# Altium schematic sheet interface.SchDoc(interface)
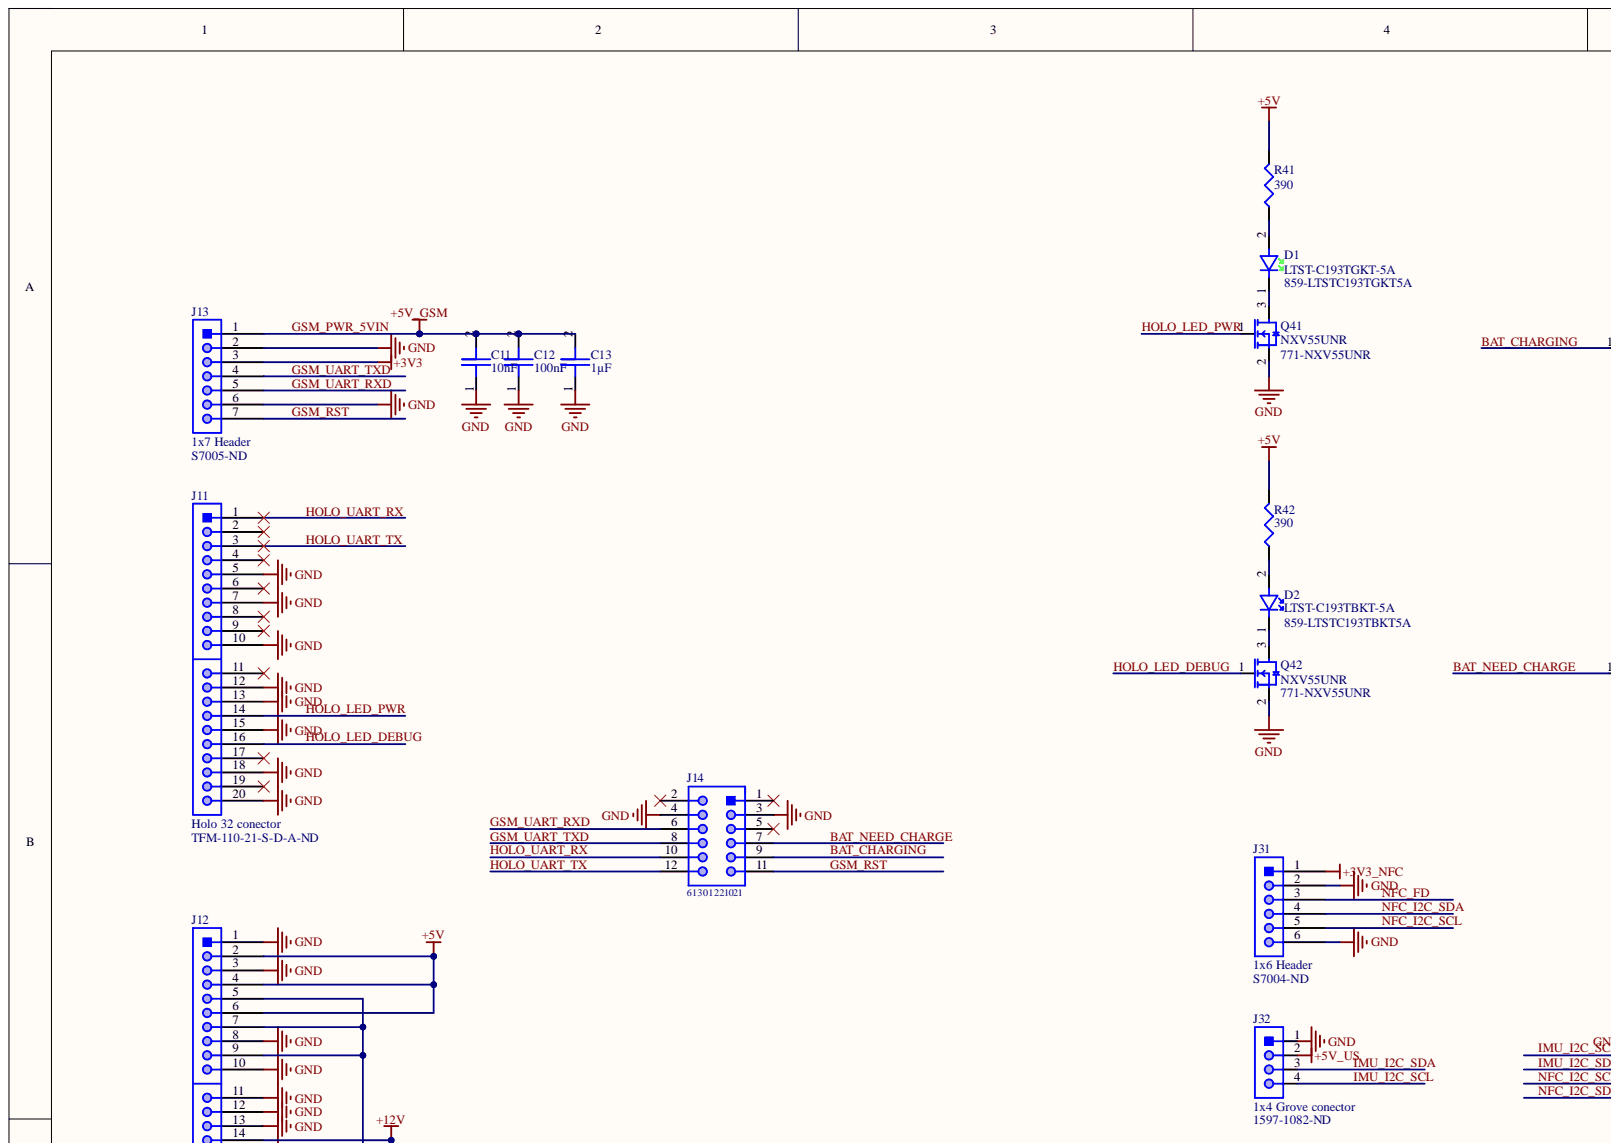
[diagram p0: interface.SchDoc, top left]
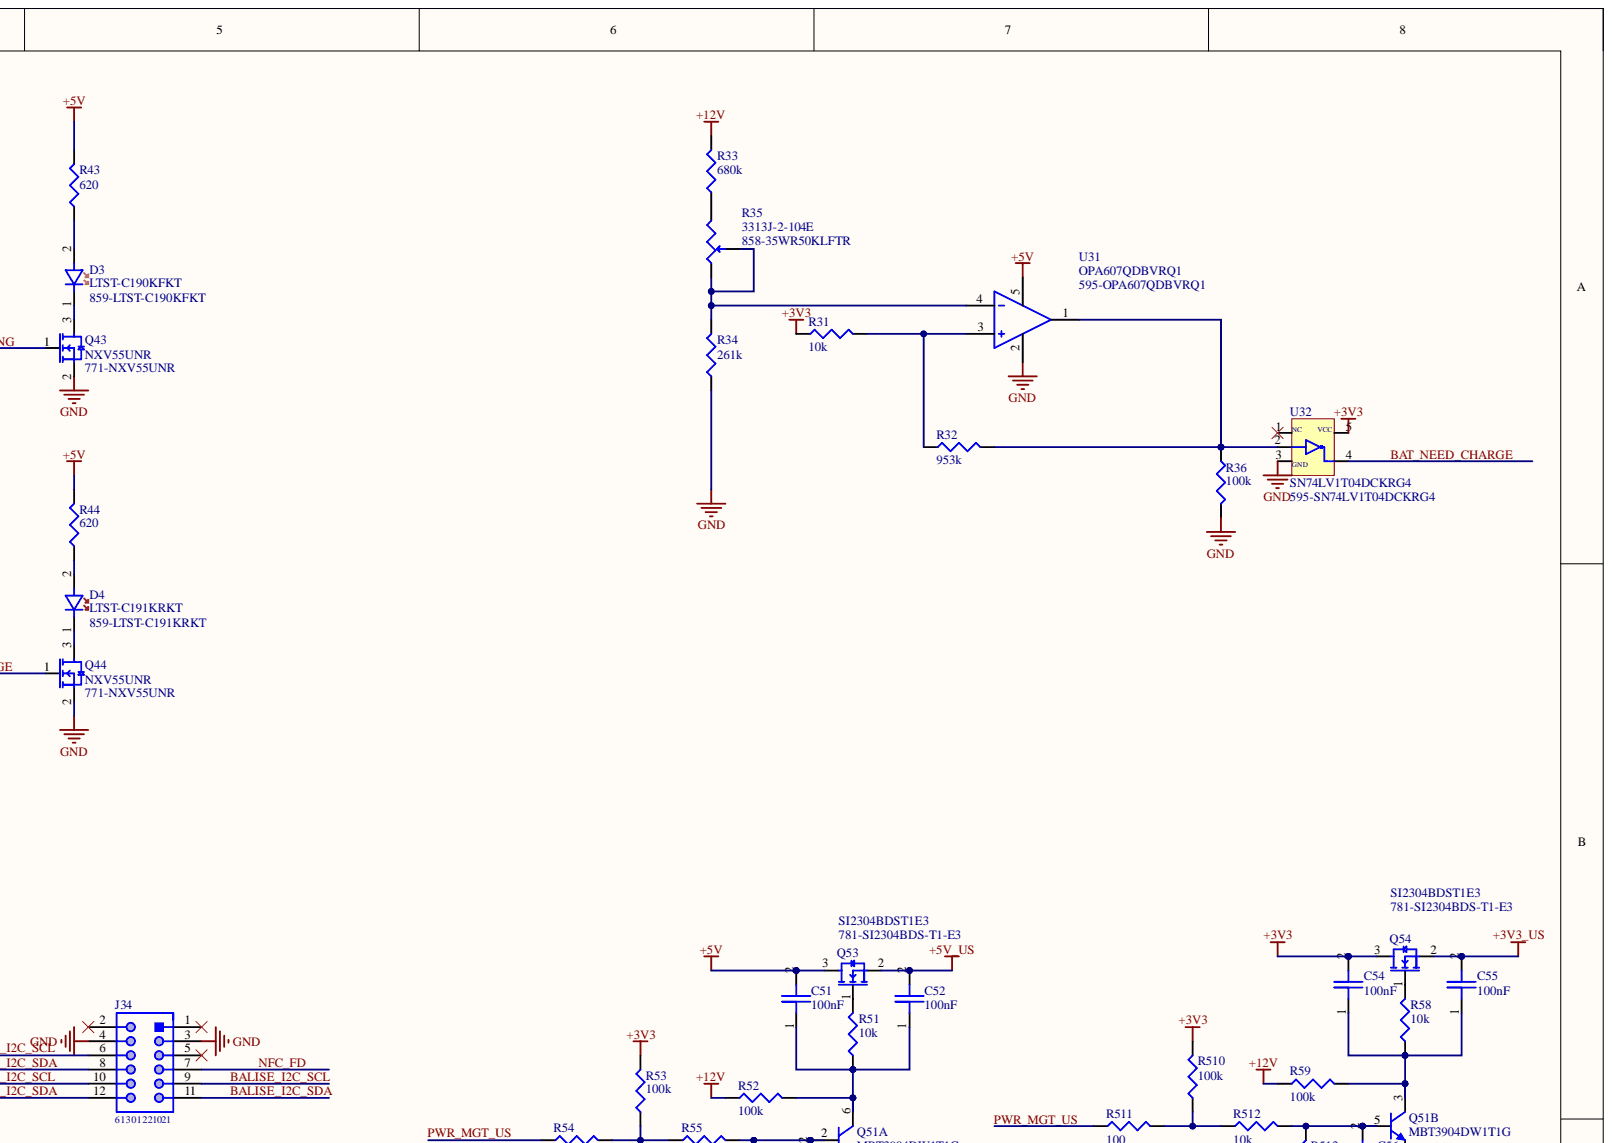
[diagram p1: interface.SchDoc, top right]
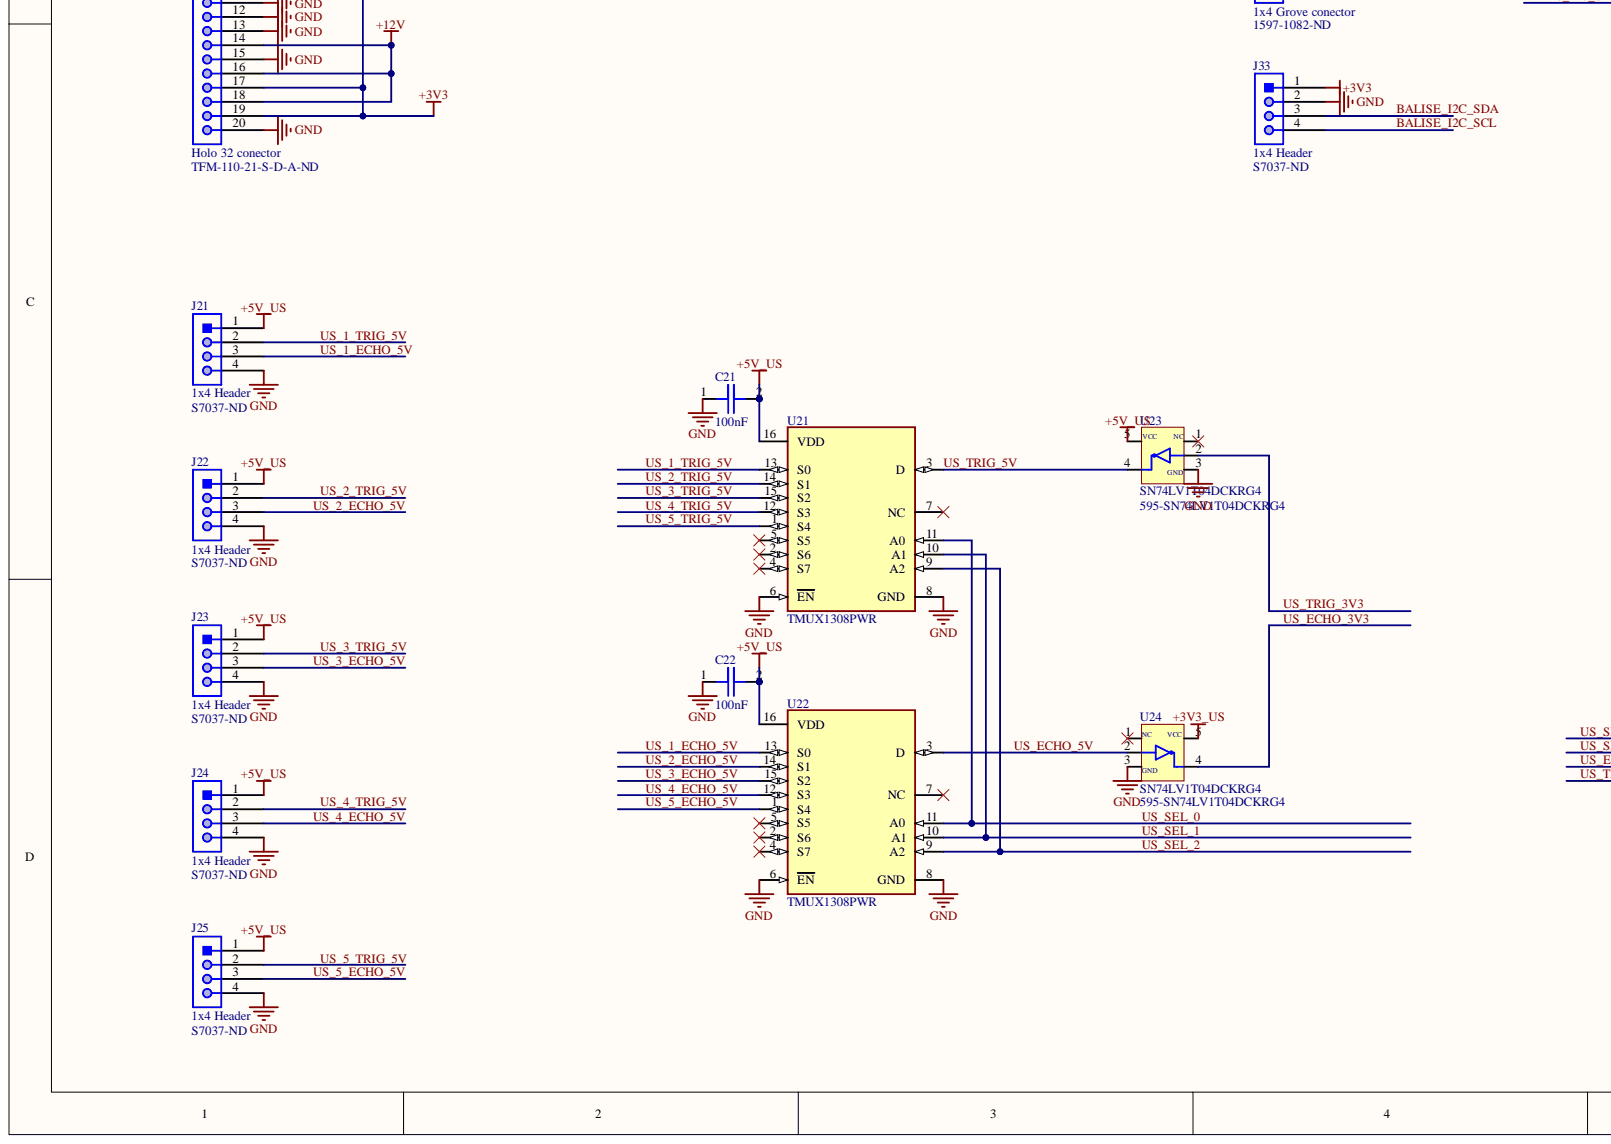
[diagram p2: interface.SchDoc, bottom left]
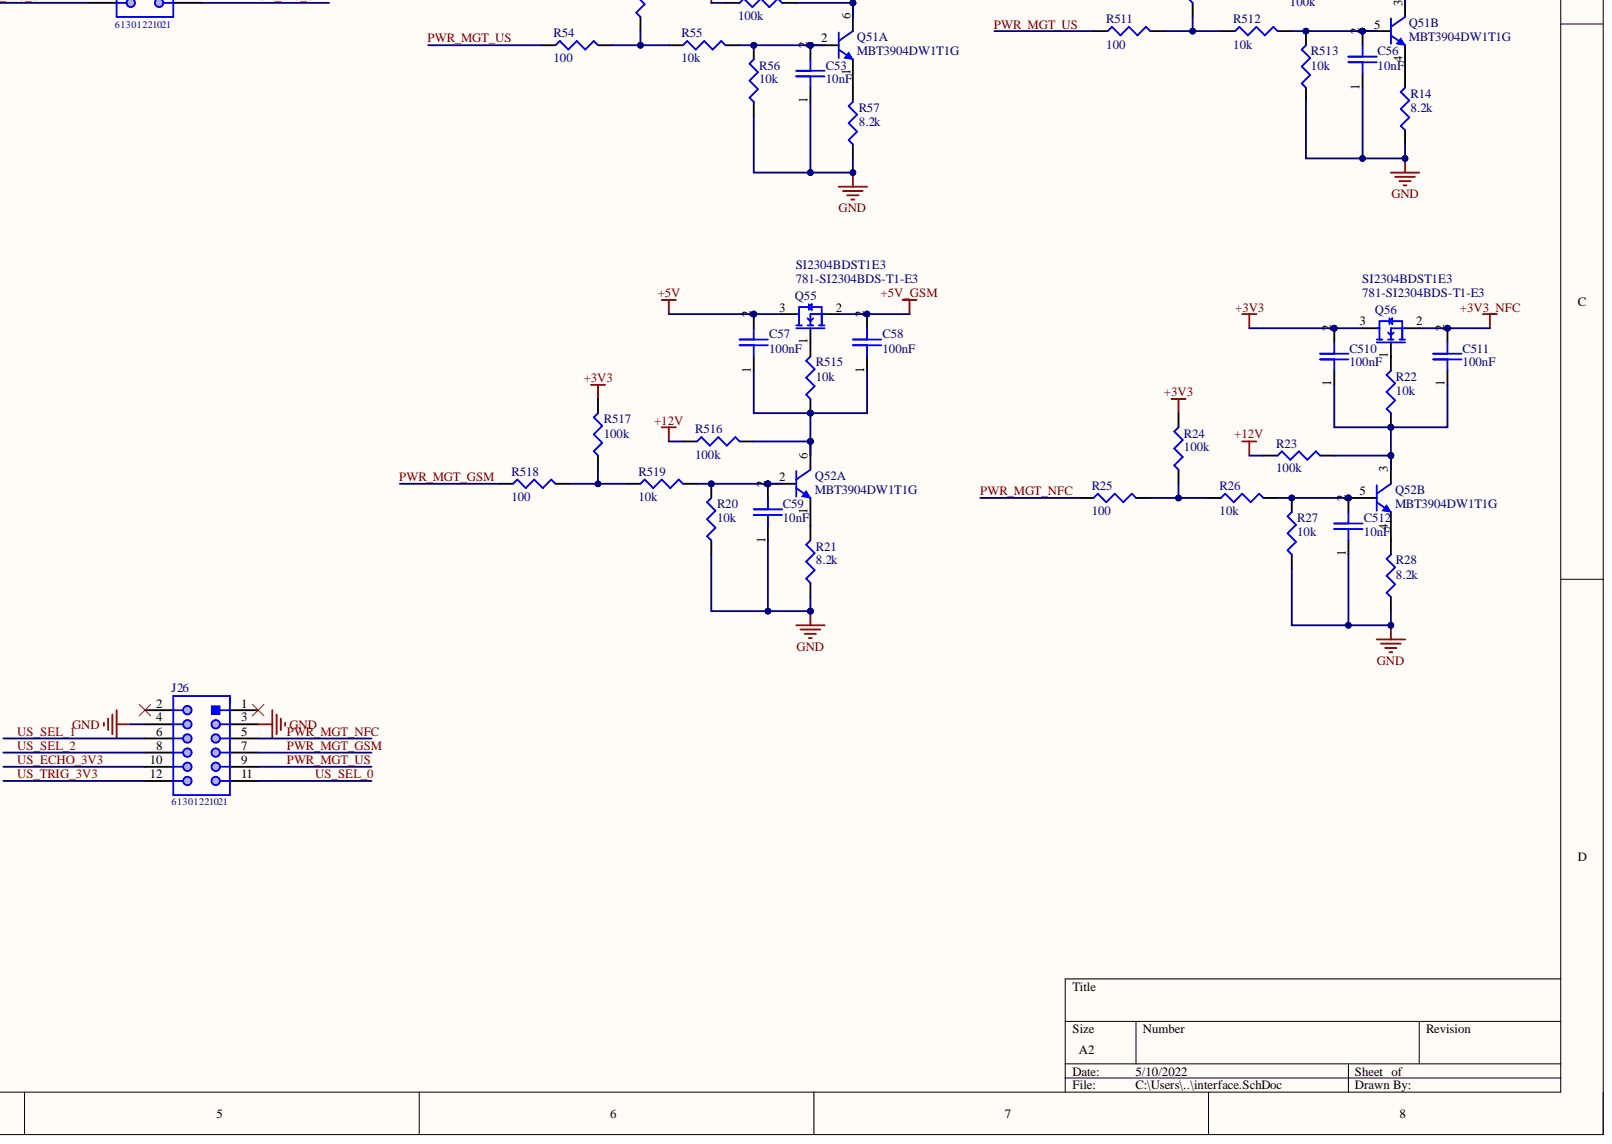
[diagram p3: interface.SchDoc, bottom right]

## Parts
C11  10nF  [FP-C0603C-CF-IPC_A]
C12  100nF  [FP-C0603C104K5RAC3121-IPC_A]
C13  1µF  [CAPC1608X90X35NL15T15]
C21  100nF  [FP-C0603C104K5RAC3121-IPC_A]
C22  100nF  [FP-C0603C104K5RAC3121-IPC_A]
C51  100nF  [FP-C0603C104K5RAC3121-IPC_A]
C52  100nF  [FP-C0603C104K5RAC3121-IPC_A]
C53  10nF  [FP-C0603C-CF-IPC_A]
C54  100nF  [FP-C0603C104K5RAC3121-IPC_A]
C55  100nF  [FP-C0603C104K5RAC3121-IPC_A]
C56  10nF  [FP-C0603C-CF-IPC_A]
C57  100nF  [FP-C0603C104K5RAC3121-IPC_A]
C58  100nF  [FP-C0603C104K5RAC3121-IPC_A]
C59  10nF  [FP-C0603C-CF-IPC_A]
C510  100nF  [FP-C0603C104K5RAC3121-IPC_A]
C511  100nF  [FP-C0603C104K5RAC3121-IPC_A]
C512  10nF  [FP-C0603C-CF-IPC_A]
D1  LTST-C193TGKT-5A  Supplier Part Number 1: 859-LTSTC193TGKT5A  [FP-LTST-C193TGKT-5A]
D2  LTST-C193TBKT-5A  Supplier Part Number 1: 859-LTSTC193TBKT5A  [FP-LTST-C193TBKT-5A-MFG]
D3  LTST-C190KFKT  Supplier Part Number 1: 859-LTST-C190KFKT  [FP-LTST-C190KFKT-MFG]
D4  LTST-C191KRKT  Supplier Part Number 1: 859-LTST-C191KRKT  [FP-LTST-C191KRKT]
J11  Holo 32 conector  Supplier Part Number 1: TFM-110-21-S-D-A-ND  [SAMTEC_TFM-110-21-S-D-A]
J12  Holo 32 conector  Supplier Part Number 1: TFM-110-21-S-D-A-ND  [SAMTEC_TFM-110-21-S-D-A]
J13  1x7 Header  Supplier Part Number 1: S7005-ND  [header 1x7]
J14  61301221021  [61301221021]
J21  1x4 Header  Connector Type: Header  Supplier Part Number 1: S7037-ND  [header 1x4]
J22  1x4 Header  Connector Type: Header  Supplier Part Number 1: S7037-ND  [header 1x4]
J23  1x4 Header  Connector Type: Header  Supplier Part Number 1: S7037-ND  [header 1x4]
J24  1x4 Header  Connector Type: Header  Supplier Part Number 1: S7037-ND  [header 1x4]
J25  1x4 Header  Connector Type: Header  Supplier Part Number 1: S7037-ND  [header 1x4]
J26  61301221021  [61301221021]
J31  1x6 Header  Supplier Part Number 1: S7004-ND  [header 1x6]
J32  1x4 Grove conector  Supplier Part Number 1: 1597-1082-ND  [FP-110990030]
J33  1x4 Header  Connector Type: Header  Supplier Part Number 1: S7037-ND  [header 1x4]
J34  61301221021  [61301221021]
Q41  NXV55UNR  Supplier Part Number 1: 771-NXV55UNR  [SOT95P230X110-3N]
Q42  NXV55UNR  Supplier Part Number 1: 771-NXV55UNR  [SOT95P230X110-3N]
Q43  NXV55UNR  Supplier Part Number 1: 771-NXV55UNR  [SOT95P230X110-3N]
Q44  NXV55UNR  Supplier Part Number 1: 771-NXV55UNR  [SOT95P230X110-3N]
Q51  MBT3904DW1T1G  [ONSC-SOT-363-6-419B-02_W_V]  (parts A,B)
Q52  MBT3904DW1T1G  [ONSC-SOT-363-6-419B-02_W_V]  (parts A,B)
Q53  SI2304BDST1E3  Supplier Part Number 1: 781-SI2304BDS-T1-E3  [FP-SI2304BDST1E3]
Q54  SI2304BDST1E3  Supplier Part Number 1: 781-SI2304BDS-T1-E3  [FP-SI2304BDST1E3]
Q55  SI2304BDST1E3  Supplier Part Number 1: 781-SI2304BDS-T1-E3  [FP-SI2304BDST1E3]
Q56  SI2304BDST1E3  Supplier Part Number 1: 781-SI2304BDS-T1-E3  [FP-SI2304BDST1E3]
R14  8.2k  [RESC2013X50X30ML20T20]
R20  10k  [RESC2013X50X30ML20T20]
R21  8.2k  [RESC2013X50X30ML20T20]
R22  10k  [RESC2013X50X30ML20T20]
R23  100k  [RESC2013X50X30ML20T20]
R24  100k  [RESC2013X50X30ML20T20]
R25  100  [RESC2013X50X30ML20T20]
R26  10k  [RESC2013X50X30ML20T20]
R27  10k  [RESC2013X50X30ML20T20]
R28  8.2k  [RESC2013X50X30ML20T20]
R31  10k  [RESC2013X50X30ML20T20]
R32  953k  [RESC2013X50X30ML20T20]
R33  680k  [RESC2013X50X30ML20T20]
R34  261k  [RESC2013X50X30ML20T20]
R35  3313J-2-104E  Supplier Part Number 1: 858-35WR50KLFTR  [FP-3313J-2-104E]
R36  100k  [RESC2013X50X30ML20T20]
R41  390  [RESC2013X50X30ML20T20]
R42  390  [RESC2013X50X30ML20T20]
R43  620  [RESC2013X50X30ML20T20]
R44  620  [RESC2013X50X30ML20T20]
R51  10k  [RESC2013X50X30ML20T20]
R52  100k  [RESC2013X50X30ML20T20]
R53  100k  [RESC2013X50X30ML20T20]
R54  100  [RESC2013X50X30ML20T20]
R55  10k  [RESC2013X50X30ML20T20]
R56  10k  [RESC2013X50X30ML20T20]
R57  8.2k  [RESC2013X50X30ML20T20]
R58  10k  [RESC2013X50X30ML20T20]
R59  100k  [RESC2013X50X30ML20T20]
R510  100k  [RESC2013X50X30ML20T20]
R511  100  [RESC2013X50X30ML20T20]
R512  10k  [RESC2013X50X30ML20T20]
R513  10k  [RESC2013X50X30ML20T20]
R515  10k  [RESC2013X50X30ML20T20]
R516  100k  [RESC2013X50X30ML20T20]
R517  100k  [RESC2013X50X30ML20T20]
R518  100  [RESC2013X50X30ML20T20]
R519  10k  [RESC2013X50X30ML20T20]
U21  TMUX1308PWR  [FP-PW0016A-IPC_A]
U22  TMUX1308PWR  [FP-PW0016A-IPC_A]
U23  SN74LV1T04DCKRG4  Supplier Part Number 1: 595-SN74LV1T04DCKRG4  [SOT65P210X110-5N]
U24  SN74LV1T04DCKRG4  Supplier Part Number 1: 595-SN74LV1T04DCKRG4  [SOT65P210X110-5N]
U31  OPA607QDBVRQ1  Supplier Part Number 1: 595-OPA607QDBVRQ1  [FP-DBV0005A-IPC_A]
U32  SN74LV1T04DCKRG4  Supplier Part Number 1: 595-SN74LV1T04DCKRG4  [SOT65P210X110-5N]

## Nets
+3V3: C54.2 C510.2 J12.5 J12.7 J12.9 J12.17 J12.19 J13.3 J33.1 Q54.3 Q56.3 R24.2 R31.1 R53.2 R510.2 R517.2 U32.5(VCC)
+3V3_NFC: C511.2 J31.1 Q56.2
+3V3_US: C55.2 Q54.2 U24.5
+5V: C51.2 C57.2 J12.2 J12.4 J12.6 Q53.3 Q55.3 R41.2 R42.2 R43.2 R44.2 U31.5
+5V_US: C21.2 C22.2 C52.2 J21.1 J22.1 J23.1 J24.1 J25.1 J32.2 Q53.2 U21.16(VDD) U22.16(VDD) U23.5(VCC)
+12V: J12.14 J12.16 J12.18 R23.1 R33.2 R52.1 R59.1 R516.1
GND: C11.1 C12.1 C13.1 C21.1 C22.1 C53.1 C56.1 C59.1 C512.1 J11.5 J11.7 J11.10 J11.12 J11.13 J11.15 J11.18 J11.20 J12.1 J12.3 J12.8 J12.10 J12.11 J12.12 J12.13 J12.15 J12.20 J13.2 J13.6 J14.3 J14.4 J21.4 J22.4 J23.4 J24.4 J25.4 J26.3 J26.4 J31.2 J31.6 J32.1 J33.2 J34.3 J34.4 Q41.2 Q42.2 Q43.2 Q44.2 R14.1 R20.1 R21.1 R27.1 R28.1 R34.1 R36.2 R56.1 R57.1 R513.1 U21.6(EN) U21.8(GND) U22.6(EN) U22.8(GND) U23.3 U24.3(GND) U31.2 U32.3
BALISE_I2C_SCL: J33.4 J34.9
BALISE_I2C_SDA: J33.3 J34.11
BAT_CHARGING: J14.9 Q43.1
BAT_NEED_CHARGE: J14.7 Q44.1 U32.4
GSM_PWR_5VIN: C11.2 C12.2 C13.2 C58.2 J13.1 Q55.2
GSM_RST: J13.7 J14.11
GSM_UART_RXD: J13.5 J14.6
GSM_UART_TXD: J13.4 J14.8
HOLO_LED_DEBUG: J11.16 Q42.1
HOLO_LED_PWR: J11.14 Q41.1
HOLO_UART_RX: J11.1 J14.10
HOLO_UART_TX: J11.3 J14.12
IMU_I2C_SCL: J32.4 J34.6
IMU_I2C_SDA: J32.3 J34.8
NFC_FD: J31.3 J34.7
NFC_I2C_SCL: J31.5 J34.10
NFC_I2C_SDA: J31.4 J34.12
PWR_MGT_GSM: J26.7 R518.1
PWR_MGT_NFC: J26.5 R25.1
PWR_MGT_US: J26.9 R54.1 R511.1
US_1_ECHO_5V: J21.3 U22.13(S0)
US_1_TRIG_5V: J21.2 U21.13(S0)
US_2_ECHO_5V: J22.3 U22.14(S1)
US_2_TRIG_5V: J22.2 U21.14(S1)
US_3_ECHO_5V: J23.3 U22.15(S2)
US_3_TRIG_5V: J23.2 U21.15(S2)
US_4_ECHO_5V: J24.3 U22.12(S3)
US_4_TRIG_5V: J24.2 U21.12(S3)
US_5_ECHO_5V: J25.3 U22.1(S4)
US_5_TRIG_5V: J25.2 U21.1(S4)
US_ECHO_3V3: J26.10 U24.4
US_ECHO_5V: U22.3(D) U24.2
US_SEL_0: J26.11 U21.11(A0) U22.11(A0)
US_SEL_1: J26.6 U21.10(A1) U22.10(A1)
US_SEL_2: J26.8 U21.9(A2) U22.9(A2)
US_TRIG_3V3: J26.12 U23.2
US_TRIG_5V: U21.3(D) U23.4
NetC51_1: C51.1 C52.1 Q51.6 R51.1 R52.2
NetC53_2: C53.2 Q51.2 R55.2 R56.2
NetC54_1: C54.1 C55.1 Q51.3 R58.1 R59.2
NetC56_2: C56.2 Q51.5 R512.2 R513.2
NetC57_1: C57.1 C58.1 Q52.6 R515.1 R516.2
NetC59_2: C59.2 Q52.2 R20.2 R519.2
NetC510_1: C510.1 C511.1 Q52.3 R22.1 R23.2
NetC512_2: C512.2 Q52.5 R26.2 R27.2
NetD1_1: D1.1 Q41.3
NetD1_2: D1.2 R41.1
NetD2_1: D2.1 Q42.3
NetD2_2: D2.2 R42.1
NetD3_1: D3.1 Q43.3
NetD3_2: D3.2 R43.1
NetD4_1: D4.1 Q44.3
NetD4_2: D4.2 R44.1
NetQ51_1: Q51.1 R57.2
NetQ51_4: Q51.4 R14.2
NetQ52_1: Q52.1 R21.2
NetQ52_4: Q52.4 R28.2
NetQ53_1: Q53.1 R51.2
NetQ54_1: Q54.1 R58.2
NetQ55_1: Q55.1 R515.2
NetQ56_1: Q56.1 R22.2
NetR24_1: R24.1 R25.2 R26.1
NetR31_2: R31.2 R32.1 U31.3
NetR32_2: R32.2 R36.1 U31.1 U32.2
NetR33_1: R33.1 R35.2
NetR34_2: R34.2 R35.1 R35.3 U31.4
NetR53_1: R53.1 R54.2 R55.1
NetR510_1: R510.1 R511.2 R512.1
NetR517_1: R517.1 R518.2 R519.1

Not connected: J11 2 4 6 8 9 11 17 19; J14 1 2 5; J26 1 2; J34 1 2 5; U21 2(S6) 4(S7) 5(S5) 7(NC); U22 2(S6) 4(S7) 5(S5) 7(NC); U23 1; U24 1; U32 1(NC)

## Library data of the parts
C11 C53 C56 C59 C512 = C0603C103K5RACTU: CAP CER 10000PF 50V X7R 0603; Manufacturer: KEMET; Dielectric Material: Ceramic; Dissipation Factor: 2.5%; Tolerance: 10%; Capacitance: 10nF; Case Code (Imperial): 0603; Case Code (Metric): 1608; Case/Package: 0603; Category: Ceramic Capacitors; Component Kind: Standard; ComponentLink1Description: https://datasheet.ciiva.com/7823/1732718-7823585.pdf?src-supplier=Element14; ComponentLink1URL: https://datasheet.ciiva.com/7823/1732718-7823585.pdf?src-supplier=Element14; ComponentLink2Description: https://datasheet.ciiva.com/5904/kem-c1002-x7r-smd-5904645.pdf?src-supplier=Farnell; ComponentLink2URL: https://datasheet.ciiva.com/5904/kem-c1002-x7r-smd-5904645.pdf?src-supplier=Farnell; ComponentLink3Description: https://datasheet.ciiva.com/7823/kem-c1002-x7r-smd-7823976.pdf?src-supplier=Element14; ComponentLink3URL: https://datasheet.ciiva.com/7823/kem-c1002-x7r-smd-7823976.pdf?src-supplier=Element14; ComponentLink4Description: https://datasheet.ciiva.com/7823/1918693-7823978.pdf?src-supplier=Element14; ComponentLink4URL: https://datasheet.ciiva.com/7823/1918693-7823978.pdf?src-supplier=Element14; ComponentLink5Description: https://datasheet.ciiva.com/28645/1851736-28645598.pdf?src-supplier=Element14; ComponentLink5URL: https://datasheet.ciiva.com/28645/1851736-28645598.pdf?src-supplier=Element14; ComponentLink6Description: https://datasheet.ciiva.com/7823/kem-c1002-x7r-smd-7823977.pdf?src-supplier=Element14; ComponentLink6URL: https://datasheet.ciiva.com/7823/kem-c1002-x7r-smd-7823977.pdf?src-supplier=Element14; ComponentLink7Description: https://datasheet.ciiva.com/14585/70095383-14585450.pdf?src-supplier=Allied+Electronics; ComponentLink7URL: https://datasheet.ciiva.com/14585/70095383-14585450.pdf?src-supplier=Allied+Electronics; ComponentLink8Description: https://datasheet.ciiva.com/5416/kem-c1002-x7r-smd-5416028.pdf?src-supplier=Mouser; ComponentLink8URL: https://datasheet.ciiva.com/5416/kem-c1002-x7r-smd-5416028.pdf?src-supplier=Mouser; ComponentLink9Description: https://datasheet.ciiva.com/39122/kem-c1002-x7r-smd-39122903.pdf?src-supplier=Onlinecomponents.com; ComponentLink9URL: https://datasheet.ciiva.com/39122/kem-c1002-x7r-smd-39122903.pdf?src-supplier=Onlinecomponents.com; ComponentLink10Description: https://datasheet.ciiva.com/18192/getdatasheetpartid-308803-18192662.pdf?src-supplier=Verical; ComponentLink10URL: https://datasheet.ciiva.com/18192/getdatasheetpartid-308803-18192662.pdf?src-supplier=Verical; ComponentLink11Description: https://datasheet.ciiva.com/18101/getdatasheetpartid-58468-18101898.pdf?src-supplier=Verical; ComponentLink11URL: https://datasheet.ciiva.com/18101/getdatasheetpartid-58468-18101898.pdf?src-supplier=Verical; Construction: Flat; Contact Plating: Tin,Matte; Depth: 0.8mm; Dielectric: X7R; Height: 0.8mm; Lead Pitch: 0.7mm; Length: 1.6mm; Library Name: interface_Schlib.SchLib; Manufacturer Part Number: C0603C103K5RACTU; Max Operating Temperature: 125°C; Min Operating Temperature: -55°C; Mount: Surface Mount,PCB; Number of Pins: 2; Package Quantity: 4000; Packaging: Tape and Reel; Pricing 1: 1=0,16000, 10=0,07400, 50=0,07400, 100=0,03300, 500=0,02400, 1000=0,01900, 2000=0,01700, 4000=0,01500, 8000=0,01300, 10000=0,01300 (USD); Radiation Hardening: No; REACH SVHC: No SVHC; RoHS: Yes; RoHS Compliant: Yes; Series: C0603C; Stock 1: 1844699; Supplier 1: Mouser; Termination: SMD/SMT; Thickness: 0.034inch; Voltage: 50V; Voltage Rating: 50V; Voltage Rating (DC): 50V; Width: 0.8mm
C12 C21 C22 C51 C52 C54 C55 C57 C58 C510 C511 = C0603C104K5RAC3121: Cap Ceramic 0.1uF 50V X7R 10% Pad SMD 0603 125°C T/R; Manufacturer: KEMET; Case/Package: 0603; Category: Ceramic Capacitors; Component Kind: Standard; Library Name: interface_Schlib.SchLib; Manufacturer Part Number: C0603C104K5RAC3121; Pricing 1: 1=0,13000, 10=0,09200, 50=0,09200, 100=0,04300, 1000=0,02500, 10000=0,01900 (USD); RoHS: Yes; RoHS Compliant: Yes; Stock 1: 3306727; Supplier 1: Mouser
C13 = C0603C105K4RACTU: Cap Ceramic 1uF 16V X7R 10% SMD 0603 125°C Paper T/R; Manufacturer: KEMET; Dissipation Factor: 3.5%; Capacitance: 1uF; Case Code (Imperial): 0603; Case Code (Metric): 1608; Case/Package: 0603; Category: Ceramic Capacitors; Component Kind: Standard; ComponentLink1Description: https://datasheet.ciiva.com/7823/kem-c1002-x7r-smd-7823997.pdf?src-supplier=Element14; ComponentLink1URL: https://datasheet.ciiva.com/7823/kem-c1002-x7r-smd-7823997.pdf?src-supplier=Element14; ComponentLink2Description: https://datasheet.ciiva.com/22749/kem-c1002-x7r-smd-22749721.pdf?src-supplier=Element14; ComponentLink2URL: https://datasheet.ciiva.com/22749/kem-c1002-x7r-smd-22749721.pdf?src-supplier=Element14; ComponentLink3Description: https://datasheet.ciiva.com/5419/kem-c1002-x7r-smd-5419750.pdf?src-supplier=Mouser; ComponentLink3URL: https://datasheet.ciiva.com/5419/kem-c1002-x7r-smd-5419750.pdf?src-supplier=Mouser; ComponentLink4Description: https://datasheet.ciiva.com/18192/getdatasheetpartid-385479-18192732.pdf?src-supplier=Verical; ComponentLink4URL: https://datasheet.ciiva.com/18192/getdatasheetpartid-385479-18192732.pdf?src-supplier=Verical; Construction: Flat; Contact Plating: Tin,Matte; Depth: 0.8mm; Dielectric: X7R; Dielectric Material: Ceramic; Height: 0.8mm; Length: 1.6mm; Library Name: interface_Schlib.SchLib; Manufacturer Part Number: C0603C105K4RACTU; Max Operating Temperature: 125°C; Min Operating Temperature: -55°C; Mount: Surface Mount,PCB; Number of Pins: 2; Package Quantity: 4000; Packaging: Tape and Reel; Pricing 1: 1=0,17000, 10=0,08000, 50=0,08000, 100=0,03600, 500=0,02600, 1000=0,02000, 2000=0,01900, 4000=0,01600, 8000=0,01400, 10000=0,01400 (USD); Radiation Hardening: No; REACH SVHC: No SVHC; RoHS: Yes; RoHS Compliant: Yes; Series: C0603C; Stock 1: 3280807; Supplier 1: Mouser; Termination: SMD/SMT; Thickness: 0.034inch; Tolerance: 10%; Voltage: 16V; Voltage Rating: 16V; Voltage Rating (DC): 16V; Width: 0.031inch
D1 = LTST-C193TGKT-5A: 0603(H=0.35mm) 绿色 λD=520nm~535nm VR=5V VF=2.5V~3.1V IF=5mA PD=76mW; Manufacturer: Vishay Lite-On; Color: Green; Dominant Wavelength: 535nm; Forward Current: 5mA; Forward Voltage: 3.1V; Lens Color: Colorless; Lens Style: Diffused,Colorless,Rectangular; Lens Transparency: Clear; Luminous Intensity: 280mcd; Number of Elements: 1; Power Dissipation: 76mW; Reverse Voltage: 5V; Viewing Angle: 130°; Case Code (Imperial): 0603; Case Code (Metric): 1608; Case/Package: 0603; Category: LEDs; Component Kind: Standard; ComponentLink1Description: https://datasheet.ciiva.com/2111/ltst-c193tgkt-5a-2111818.pdf?src-supplier=Digi-Key; ComponentLink1URL: https://datasheet.ciiva.com/2111/ltst-c193tgkt-5a-2111818.pdf?src-supplier=Digi-Key; ComponentLink2Description: https://datasheet.ciiva.com/11775/0900766b80dc0555-11775617.pdf?src-supplier=RSComponents; ComponentLink2URL: https://datasheet.ciiva.com/11775/0900766b80dc0555-11775617.pdf?src-supplier=RSComponents; ComponentLink3Description: https://datasheet.ciiva.com/4689/lite-on-ltst-c193tgkt-5a-187259-4689610.pdf?src-supplier=Mouser; ComponentLink3URL: https://datasheet.ciiva.com/4689/lite-on-ltst-c193tgkt-5a-187259-4689610.pdf?src-supplier=Mouser; ComponentLink4Description: https://datasheet.ciiva.com/2111/p-100-ltst-c193tgkt-5a-2111819.pdf?src-supplier=Digi-Key; ComponentLink4URL: https://datasheet.ciiva.com/2111/p-100-ltst-c193tgkt-5a-2111819.pdf?src-supplier=Digi-Key; ComponentLink5Description: https://datasheet.ciiva.com/2111/p-100-ltst-c193tgkt-5a-2111820.pdf?src-supplier=Digi-Key; ComponentLink5URL: https://datasheet.ciiva.com/2111/p-100-ltst-c193tgkt-5a-2111820.pdf?src-supplier=Digi-Key; Depth: 0.8mm; Height: 0.35mm; Illumination Color: Green; Lead Free: Lead Free; Length: 1.6mm; Library Name: interface_Schlib.SchLib; Manufacturer Part Number: LTST-C193TGKT-5A; Max Operating Temperature: 80°C; Min Operating Temperature: -20°C; Mount: Surface Mount; Number of LEDs: 1; Number of Pins: 2; Package Quantity: 3000; Packaging: Tape and Reel; Pricing 1: 1=0,44000, 10=0,27500, 50=0,27500, 100=0,15800, 1000=0,12400, 5000=0,11300, 10000=0,09600, 25000=0,09200, 50000=0,08900 (USD); Radiation Hardening: No; RoHS: Yes; RoHS Compliant: Yes; Series: -; Stock 1: 78292; Supplier 1: Mouser; Test Current: 5mA; Width: 0.8mm
D2 = LTST-C193TBKT-5A: 0603(H=0.35mm) 蓝色 λD=465nm~475nm VR=5V VF=2.65V~3.05V IF=5mA PD=76mW; Manufacturer: Vishay Lite-On; Color: Blue; Dominant Wavelength: 475nm; Forward Current: 20mA; Forward Voltage: 2.8V; Lens Color: Colorless; Lens Style: Diffused,Colorless,Rectangular,Clear; Lens Transparency: Clear; Luminous Intensity: 15mcd; Number of Elements: 1; Power Dissipation: 76mW; Reverse Voltage: 5V; Test Current: 5mA; Viewing Angle: 130°; Case Code (Imperial): 0603; Case Code (Metric): 1608; Case/Package: 0603; Category: LEDs; Component Kind: Standard; ComponentLink1Description: https://datasheet.ciiva.com/2111/ltst-c193tbkt-5a-2111219.pdf?src-supplier=Digi-Key; ComponentLink1URL: https://datasheet.ciiva.com/2111/ltst-c193tbkt-5a-2111219.pdf?src-supplier=Digi-Key; ComponentLink2Description: https://datasheet.ciiva.com/11773/0900766b80dc0554-11773880.pdf?src-supplier=RSComponents; ComponentLink2URL: https://datasheet.ciiva.com/11773/0900766b80dc0554-11773880.pdf?src-supplier=RSComponents; ComponentLink3Description: https://datasheet.ciiva.com/4688/lite-on-ltst-c193tbkt-5a-187386-4688832.pdf?src-supplier=Mouser; ComponentLink3URL: https://datasheet.ciiva.com/4688/lite-on-ltst-c193tbkt-5a-187386-4688832.pdf?src-supplier=Mouser; ComponentLink4Description: https://datasheet.ciiva.com/16210/ltst-c193tbkt-5a-16210824.pdf?src-supplier=Future+Electronics; ComponentLink4URL: https://datasheet.ciiva.com/16210/ltst-c193tbkt-5a-16210824.pdf?src-supplier=Future+Electronics; ComponentLink5Description: https://datasheet.ciiva.com/15201/getdatasheetpartid-293056-15201395.pdf?src-supplier=Verical; ComponentLink5URL: https://datasheet.ciiva.com/15201/getdatasheetpartid-293056-15201395.pdf?src-supplier=Verical; ComponentLink6Description: https://datasheet.ciiva.com/2111/p-100-ltst-c193tbkt-5a-2111220.pdf?src-supplier=Digi-Key; ComponentLink6URL: https://datasheet.ciiva.com/2111/p-100-ltst-c193tbkt-5a-2111220.pdf?src-supplier=Digi-Key; ComponentLink7Description: https://datasheet.ciiva.com/2111/p-100-ltst-c193tbkt-5a-2111221.pdf?src-supplier=Digi-Key; ComponentLink7URL: https://datasheet.ciiva.com/2111/p-100-ltst-c193tbkt-5a-2111221.pdf?src-supplier=Digi-Key; Depth: 0.8mm; Height: 0.35mm; Illumination Color: Blue; Lead Free: Lead Free; Length: 1.6mm; Library Name: interface_Schlib.SchLib; Manufacturer Part Number: LTST-C193TBKT-5A; Max Operating Temperature: 80°C; Min Operating Temperature: -20°C; Mount: Surface Mount; Number of LEDs: 1; Number of Pins: 2; Package Quantity: 1; Packaging: Tape and Reel; Pricing 1: 1=0,30000, 10=0,23400, 50=0,23400, 100=0,10600, 500=0,09500, 1000=0,07800, 5000=0,06700, 10000=0,05400, 25000=0,05000, 50000=0,04500 (USD); Radiation Hardening: No; RoHS: Yes; RoHS Compliant: Yes; Stock 1: 327691; Supplier 1: Mouser; Width: 0.8mm
D3 = LTST-C190KFKT: 0603(H=0.9mm) 橙色 λD=605nm VR=5V VF=2.0V~2.4V IF=20mA PD=75mW; Manufacturer: Vishay Lite-On; Color: Orange; Dominant Wavelength: 605nm; Forward Current: 30mA; Forward Voltage: 2V; Lens Color: Colorless; Lens Style: Diffused,Colorless,Clear,Rectangular; Lens Transparency: Clear; Luminous Intensity: 90mcd; Number of Elements: 1; Power Dissipation: 75mW; Reverse Voltage: 5V; Test Current: 20mA; Viewing Angle: 130°; Wavelength: 611nm; Case Code (Imperial): 0603; Case Code (Metric): 1608; Case/Package: 0603; Category: LEDs; Component Kind: Standard; ComponentLink1Description: https://datasheet.ciiva.com/11774/0900766b80dc02a7-11774744.pdf?src-supplier=RSComponents; ComponentLink1URL: https://datasheet.ciiva.com/11774/0900766b80dc02a7-11774744.pdf?src-supplier=RSComponents; ComponentLink2Description: https://datasheet.ciiva.com/12400/100-ltst-c190kfkt-53640-12400769.pdf?src-supplier=Mouser; ComponentLink2URL: https://datasheet.ciiva.com/12400/100-ltst-c190kfkt-53640-12400769.pdf?src-supplier=Mouser; ComponentLink3Description: https://datasheet.ciiva.com/16396/lite-on20ltst-c190kfkt-16396246.pdf?src-supplier=Future+Electronics; ComponentLink3URL: https://datasheet.ciiva.com/16396/lite-on20ltst-c190kfkt-16396246.pdf?src-supplier=Future+Electronics; ComponentLink4Description: https://datasheet.ciiva.com/15201/getdatasheetpartid-309341-15201388.pdf?src-supplier=Verical; ComponentLink4URL: https://datasheet.ciiva.com/15201/getdatasheetpartid-309341-15201388.pdf?src-supplier=Verical; ComponentLink5Description: https://datasheet.ciiva.com/2109/s-110-ltst-c190kfkt-2109977.pdf?src-supplier=Digi-Key; ComponentLink5URL: https://datasheet.ciiva.com/2109/s-110-ltst-c190kfkt-2109977.pdf?src-supplier=Digi-Key; ComponentLink6Description: https://datasheet.ciiva.com/2109/s-110-ltst-c190kfkt-2109976.pdf?src-supplier=Digi-Key; ComponentLink6URL: https://datasheet.ciiva.com/2109/s-110-ltst-c190kfkt-2109976.pdf?src-supplier=Digi-Key; ComponentLink7Description: https://datasheet.ciiva.com/2109/s-110-ltst-c190kfkt-2109978.pdf?src-supplier=Digi-Key; ComponentLink7URL: https://datasheet.ciiva.com/2109/s-110-ltst-c190kfkt-2109978.pdf?src-supplier=Digi-Key; Depth: 1.6mm; Height: 0.8mm; Illumination Color: Orange; Lead Free: Lead Free; Length: 3.2mm; Library Name: interface_Schlib.SchLib; Manufacturer Part Number: LTST-C190KFKT; Max Operating Temperature: 85°C; Min Operating Temperature: -55°C; Mount: Surface Mount; Number of LEDs: 1; Number of Pins: 2; Package Quantity: 1; Packaging: Tape and Reel; Power Rating: 75mW; Pricing 1: 1=0,22000, 10=0,12300, 50=0,12300, 100=0,05700, 500=0,05300, 1000=0,04200, 4000=0,04000, 8000=0,03100, 10000=0,03100, 24000=0,02800, 48000=0,02500 (USD); Radiation Hardening: No; RoHS: Yes; RoHS Compliant: Yes; Stock 1: 88067; Supplier 1: Mouser; Width: 0.8mm
D4 = LTST-C191KRKT: 0603(H=0.65mm) 红色 λD=631nm VR=5V VF=2.0V~2.4V IF=20mA PD=75mW; Manufacturer: Vishay Lite-On; Color: Red; Dominant Wavelength: 631nm; Forward Current: 30mA; Forward Voltage: 2V; Lens Color: Colorless; Lens Style: Clear,Diffused,Colorless,Rectangular; Lens Transparency: Clear; Luminous Intensity: 54mcd; Number of Elements: 1; Power Dissipation: 75mW; Reverse Voltage: 5V; Test Current: 20mA; Viewing Angle: 130°; Wavelength: 639nm; Case Code (Imperial): 0603; Case Code (Metric): 1608; Case/Package: 0603; Category: LEDs; Component Kind: Standard; ComponentLink1Description: https://datasheet.ciiva.com/11773/0900766b80dc02b2-11773601.pdf?src-supplier=RSComponents; ComponentLink1URL: https://datasheet.ciiva.com/11773/0900766b80dc02b2-11773601.pdf?src-supplier=RSComponents; ComponentLink2Description: https://datasheet.ciiva.com/12400/lite-on-ltst-c191krkt-190135-12400910.pdf?src-supplier=Mouser; ComponentLink2URL: https://datasheet.ciiva.com/12400/lite-on-ltst-c191krkt-190135-12400910.pdf?src-supplier=Mouser; ComponentLink3Description: https://datasheet.ciiva.com/16212/ltst-c191krkt-16212419.pdf?src-supplier=Future+Electronics; ComponentLink3URL: https://datasheet.ciiva.com/16212/ltst-c191krkt-16212419.pdf?src-supplier=Future+Electronics; ComponentLink4Description: https://datasheet.ciiva.com/15117/getdatasheetpartid-141922-15117737.pdf?src-supplier=Verical; ComponentLink4URL: https://datasheet.ciiva.com/15117/getdatasheetpartid-141922-15117737.pdf?src-supplier=Verical; ComponentLink5Description: https://datasheet.ciiva.com/2109/s-110-ltst-c191krkt-2109971.pdf?src-supplier=Digi-Key; ComponentLink5URL: https://datasheet.ciiva.com/2109/s-110-ltst-c191krkt-2109971.pdf?src-supplier=Digi-Key; ComponentLink6Description: https://datasheet.ciiva.com/2109/s-110-ltst-c191krkt-2109970.pdf?src-supplier=Digi-Key; ComponentLink6URL: https://datasheet.ciiva.com/2109/s-110-ltst-c191krkt-2109970.pdf?src-supplier=Digi-Key; ComponentLink7Description: https://datasheet.ciiva.com/2109/s-110-ltst-c191krkt-2109972.pdf?src-supplier=Digi-Key; ComponentLink7URL: https://datasheet.ciiva.com/2109/s-110-ltst-c191krkt-2109972.pdf?src-supplier=Digi-Key; Depth: 0.8mm; Height: 0.55mm; Illumination Color: Red; Lead Free: Lead Free; Length: 1.6mm; Library Name: interface_Schlib.SchLib; Manufacturer Part Number: LTST-C191KRKT; Max Operating Temperature: 85°C; Min Operating Temperature: -55°C; Mount: Surface Mount; Number of LEDs: 1; Number of Pins: 2; Package Quantity: 1; Packaging: Tape and Reel; Power Rating: 75mW; Pricing 1: 1=0,26000, 10=0,14200, 50=0,14200, 100=0,06600, 500=0,06100, 1000=0,04800, 5000=0,04600, 10000=0,03600, 25000=0,03200, 50000=0,02900 (USD); Radiation Hardening: No; RoHS: Yes; RoHS Compliant: Yes; Stock 1: 594160; Supplier 1: Mouser; Voltage: 2V; Width: 0.8mm
J11 J12 = TFM-110-21-S-D-A: Headers & Wire Housings High-Reliability Tiger Eye Terminal Strips, .050 Pitch; Manufacturer: Samtec; Gender: Male; Max Current Rating: 5A; Max Voltage Rating (AC): 350V; Number of Contacts: 20; Pitch: 1.27mm; Category: Connectors; Component Kind: Standard; ComponentLink1Description: http://www.farnell.com/datasheets/2047776.pdf?src-supplier=Farnell; ComponentLink1URL: http://www.farnell.com/datasheets/2047776.pdf?src-supplier=Farnell; ComponentLink2Description: http://components.arrow.com/part/dataview/2691576S7516801N1004?src-supplier=Arrow+Electronics; ComponentLink2URL: http://components.arrow.com/part/dataview/2691576S7516801N1004?src-supplier=Arrow+Electronics; ComponentLink3Description: http://www.samtec.com/documents/webfiles/pdf/TFM_SM.PDF?src-supplier=Avnet; ComponentLink3URL: http://www.samtec.com/documents/webfiles/pdf/TFM_SM.PDF?src-supplier=Avnet; Contact Material: Bronze; Contact Plating: Gold; Depth: 5.72mm; Housing Color: Black; Housing Material: Polymer; Length: 15.88mm; Library Name: interface_Schlib.SchLib; Manufacturer Part Number: TFM-110-21-S-D-A; Max Operating Temperature: 125°C; Min Operating Temperature: -55°C; Number of Rows: 2; Orientation: Straight; Packaging: Rail/Tube; Pricing 1: 1=8,39000, 35=7,16771, 105=6,64333, 455=5,59440, 945=4,89510 (USD); REACH SVHC: No SVHC; RoHS: Yes; RoHS Compliant: Yes; Stock 1: 45; Supplier 1: Digi-Key; Termination: Solder
J13 = PPTC071LFBN-RC: Female, 7C, Straight, .100"CC; 3.20mm Tail, No Mt. 100u" Tin; PBT, Phos Bronze, 105 C; Manufacturer: Sullins; Connector Type: Header,Socket; Number of Positions: 7; Pitch: 0.1inch; Category: Connectors; Color: Black; Component Kind: Standard; ComponentLink1Description: https://media.digikey.com/pdf/Data%20Sheets/Sullins%20PDFs/Female_Headers.100_DS.pdf?src-supplier=Digi-Key; ComponentLink1URL: https://media.digikey.com/pdf/Data%20Sheets/Sullins%20PDFs/Female_Headers.100_DS.pdf?src-supplier=Digi-Key; ComponentLink2Description: http://www.sullinscorp.com/catalogs/78_Page80-81_HEADER_.100_2.54mm_FEMALE.pdf?src-supplier=Future+Electronics; ComponentLink2URL: http://www.sullinscorp.com/catalogs/78_Page80-81_HEADER_.100_2.54mm_FEMALE.pdf?src-supplier=Future+Electronics; Contact Material: Bronze; Contact Plating: Tin; Height: 8.5mm; Housing Material: Plastic; Lead Free: Lead Free; Length: 18.3mm; Library Name: interface_Schlib.SchLib; Manufacturer Part Number: PPTC071LFBN-RC; Mount: Through Hole; Number of Rows: 1; Package Quantity: 1000; Packaging: Bulk; Pricing 1: 1=0,59000, 10=0,51500, 80=0,42438, 440=0,36900, 1000=0,30442, 5000=0,26752, 10000=0,26650 (USD); RoHS: Yes; Stock 1: 23266; Supplier 1: Digi-Key; Termination: Solder; Width: 2.5mm
J14 J26 J34 = 61301221021: THT Angled Pin Header WR-PHD, Pitch 2.54 mm, Dual Row, 12 pins; Manufacturer: Wurth Elektronik; Contact Resistance: 20mOhm; Gender: Male; Pin Number: 12; Pitch: 2.54mm; Rated Current: 3A; Withstanding Voltage: 500VAC; Working Voltage: 250VAC; Component Kind: Standard; Datasheet URL: https://katalog.we-online.de/em/datasheet/6130xx21021.pdf; Library Name: interface_Schlib.SchLib; Manufacturer URL: http://www.we-online.de; Mounting Technology: THT; Operating Temperature: -40to105degC; Orientation: Angled; Package Reference: 61301221021; Packaging: Bag; Part Number: 61301221021; Row Number: Dual; Publisher: WurthElektronik
J21-J25 J33 = PPPC041LFBN-RC: 4 Positions Embase Connecteur 0,100 po (2,54mm) Trou traversant Or; Manufacturer: Sullins; Number of Positions: 4; Pitch: 0.1inch; Category: Connectors; Color: Black; Component Kind: Standard; ComponentLink1Description: https://media.digikey.com/pdf/Data%20Sheets/Sullins%20PDFs/Female_Headers.100_DS.pdf?src-supplier=Digi-Key; ComponentLink1URL: https://media.digikey.com/pdf/Data%20Sheets/Sullins%20PDFs/Female_Headers.100_DS.pdf?src-supplier=Digi-Key; ComponentLink2Description: http://www.sullinscorp.com/catalogs/78_Page80-81_HEADER_.100_2.54mm_FEMALE.pdf?src-supplier=Future+Electronics; ComponentLink2URL: http://www.sullinscorp.com/catalogs/78_Page80-81_HEADER_.100_2.54mm_FEMALE.pdf?src-supplier=Future+Electronics; Contact Material: Bronze; Contact Plating: Gold; Contact Type: Female Socket; Height: 8.5mm; Housing Material: Plastic; Lead Free: Lead Free; Length: 10.7mm; Library Name: interface_Schlib.SchLib; Manufacturer Part Number: PPPC041LFBN-RC; Mount: Through Hole; Number of Rows: 1; Package Quantity: 1000; Packaging: Bulk; Pricing 1: 1=0,47000, 10=0,40700, 100=0,33530, 500=0,29160, 1000=0,24057, 5000=0,21141, 10000=0,21060 (USD); RoHS: Yes; RoHS Compliant: Yes; Stock 1: 100451; Supplier 1: Digi-Key; Termination: Solder; Width: 2.5mm
J31 = PPTC061LFBN-RC: Female, 6 C, Straight, .100"CC; 3.20mm Tail, No Mt. 100u" Tin; PBT, Phos Bronze, 105 C; Manufacturer: Sullins; Category: Connectors; Component Kind: Standard; ComponentLink1Description: https://media.digikey.com/pdf/Data%20Sheets/Sullins%20PDFs/Female_Headers.100_DS.pdf?src-supplier=Digi-Key; ComponentLink1URL: https://media.digikey.com/pdf/Data%20Sheets/Sullins%20PDFs/Female_Headers.100_DS.pdf?src-supplier=Digi-Key; ComponentLink2Description: http://www.sullinscorp.com/catalogs/78_Page80-81_HEADER_.100_2.54mm_FEMALE.pdf?src-supplier=Future+Electronics; ComponentLink2URL: http://www.sullinscorp.com/catalogs/78_Page80-81_HEADER_.100_2.54mm_FEMALE.pdf?src-supplier=Future+Electronics; Library Name: interface_Schlib.SchLib; Manufacturer Part Number: PPTC061LFBN-RC; Pricing 1: 1=0,52000, 10=0,44900, 80=0,37050, 440=0,32220, 1000=0,26582, 5000=0,23360, 10000=0,23270 (USD); RoHS: Yes; Stock 1: 131305; Supplier 1: Digi-Key
J32 = 110990030: Grove - Universal 4 pin connector [Seeed Studio] 110990030 Grove - Universal 4 pin connector; Category: Connectors; Component Kind: Standard; ComponentLink1Description: http://www.mouser.com/ds/2/744/Seeed_316010005-786598.pdf?src-supplier=Mouser; ComponentLink1URL: http://www.mouser.com/ds/2/744/Seeed_316010005-786598.pdf?src-supplier=Mouser; ComponentLink2Description: https://statics3.seeedstudio.com/images/opl/datasheet/3470130P1.pdf?src-supplier=Digi-Key; ComponentLink2URL: https://statics3.seeedstudio.com/images/opl/datasheet/3470130P1.pdf?src-supplier=Digi-Key; Library Name: interface_Schlib.SchLib; Manufacturer: Seeed Studio; Manufacturer Part Number: 110990030; Pricing 1: 1=1,70000 (USD); RoHS: No; Stock 1: 3588; Supplier 1: Digi-Key
Q41-Q44 = NXV55UNR: Transistor MOSFET N-Channel 30V 2.3A 3-Pin SOT-23; Manufacturer: Nexperia; Component Kind: Standard; Library Name: interface_Schlib.SchLib; Manufacturer Part Number: NXV55UNR; Pricing 1: 1=0,45000, 10=0,34400, 50=0,34400, 100=0,19500, 1000=0,09900, 10000=0,06800 (USD); RoHS: No; Stock 1: 55565; Supplier 1: Mouser
Q51 Q52 = CMP-1048-00877-1: Dual General Purpose Transistors, 6-Pin SOT-363, Pb-Free, Tape and Reel; Manufacturer: On Semiconductor; fT Min (MHz): 300; IC Continuous (A): 0.2; Polarity: Dual NPN; Component Kind: Standard; ComponentLink1Description: Manufacturer URL; ComponentLink1URL: http://www.onsemi.com/; ComponentLink2Description: Datasheet; ComponentLink2URL: http://www.onsemi.com/pub_link/Collateral/MBT3904DW1T1-D.PDF; ComponentLink3Description: Package Specification; ComponentLink3URL: http://www.onsemi.com/pub_link/Collateral/NTJD4001N-D.PDF; DatasheetVersion: Rev. 9; Library Name: interface_Schlib.SchLib; Mounting Technology: Surface Mount; PackageDescription: 6-Pin Small Outline Transistor, Body 2 x 1.25 mm, Pitch 0.65 mm; PackageReference: SOT-363-6-419B-02_W; PackageVersion: Rev. W, 01/2006; Packing: Tape and Reel; PartNumber: MBT3904DW1T1G; RoHS: TRUE
Q53-Q56 = SI2304BDST1E3: Single N-Channel 30 V 0.07 Ohms Surface Mount Power Mosfet - SOT-23; Manufacturer: Vishay; Continuous Drain Current (ID): 2.6A; Drain to Source Breakdown Voltage: 30V; Drain to Source Resistance: 70mR; Fall Time: 12.5ns; Gate to Source Voltage (Vgs): 20V; Input Capacitance: 225pF; Max Power Dissipation: 750mW; Nominal Vgs: 1.5V; Number of Channels: 1; Turn-Off Delay Time: 19ns; Turn-On Delay Time: 7.5ns; Case/Package: SOT-23; Category: MOSFETs; Component Kind: Standard; ComponentLink1Description: https://datasheet.ciiva.com/16225/si2304bds-16225072.pdf?src-supplier=Future+Electronics; ComponentLink1URL: https://datasheet.ciiva.com/16225/si2304bds-16225072.pdf?src-supplier=Future+Electronics; ComponentLink2Description: https://datasheet.ciiva.com/22707/1674170-22707810.pdf?src-supplier=Element14; ComponentLink2URL: https://datasheet.ciiva.com/22707/1674170-22707810.pdf?src-supplier=Element14; ComponentLink3Description: https://datasheet.ciiva.com/4439/si2304bds-107231-4439578.pdf?src-supplier=Mouser; ComponentLink3URL: https://datasheet.ciiva.com/4439/si2304bds-107231-4439578.pdf?src-supplier=Mouser; ComponentLink4Description: https://datasheet.ciiva.com/11161/si2304bds-11161450.pdf?src-supplier=Avnet; ComponentLink4URL: https://datasheet.ciiva.com/11161/si2304bds-11161450.pdf?src-supplier=Avnet; ComponentLink5Description: https://datasheet.ciiva.com/6367/si2304bds-6367035.pdf?src-supplier=Digi-Key; ComponentLink5URL: https://datasheet.ciiva.com/6367/si2304bds-6367035.pdf?src-supplier=Digi-Key; ComponentLink6Description: https://datasheet.ciiva.com/46386/1912647-46386540.pdf?src-supplier=Element14; ComponentLink6URL: https://datasheet.ciiva.com/46386/1912647-46386540.pdf?src-supplier=Element14; ComponentLink7Description: https://datasheet.ciiva.com/6367/si2304bds-6367038.pdf?src-supplier=Digi-Key; ComponentLink7URL: https://datasheet.ciiva.com/6367/si2304bds-6367038.pdf?src-supplier=Digi-Key; Drain to Source Voltage (Vdss): 30V; Element Configuration: Single; Height: 1.02mm; Lead Free: Lead Free; Length: 3.04mm; Library Name: interface_Schlib.SchLib; Manufacturer Part Number: SI2304BDST1E3; Max Operating Temperature: 150°C; Min Operating Temperature: -55°C; Mount: Surface Mount; Number of Elements: 1; Number of Pins: 3; On-State Resistance: 70mR; Package Quantity: 1; Packaging: Tape and Reel; Power Dissipation: 750mW; Pricing 1: 1=0,67000, 10=0,57800, 50=0,57800, 100=0,43200, 500=0,33900, 1000=0,26200, 3000=0,23800, 10000=0,23800 (USD); Radiation Hardening: No; Rds On Max: 70mR; REACH SVHC: Unknown; Rise Time: 12.5ns; RoHS: Yes; Stock 1: 13203; Supplier 1: Mouser; Threshold Voltage: 1.5V; Weight: 0.050717oz; Width: 1.4mm
R14 R20-R28 R31-R34 R36 R41-R44 R51-R59 R510-R513 R515-R519 = resistor: Component Kind: Standard; Library Name: interface_Schlib.SchLib
R35 = 35WR50KLFTR: 35W Series 3 mm Open Frame 50 kOhm ±25 % Single-Turn Trimming Potentiometer; Manufacturer: TT BI; Insulation Resistance: 100MR; Number of Turns: 1; Power Rating: 100mW; Resistance: 50kR; Temperature Coefficient: 250ppm/°C; Tolerance: 25%; Voltage Rating: 50V; Category: Variable Resistors and Potentiometers; Component Kind: Standard; ComponentLink1Description: https://datasheet.ciiva.com/8020/4701-8020823.pdf?src-supplier=Element14; ComponentLink1URL: https://datasheet.ciiva.com/8020/4701-8020823.pdf?src-supplier=Element14; ComponentLink2Description: https://datasheet.ciiva.com/7634/4701-7634925.pdf?src-supplier=Element14; ComponentLink2URL: https://datasheet.ciiva.com/7634/4701-7634925.pdf?src-supplier=Element14; ComponentLink3Description: https://datasheet.ciiva.com/12374/35-2231-12374697.pdf?src-supplier=Mouser; ComponentLink3URL: https://datasheet.ciiva.com/12374/35-2231-12374697.pdf?src-supplier=Mouser; Lead Free: Lead Free; Library Name: interface_Schlib.SchLib; Manufacturer Part Number: 35WR50KLFTR; Max Operating Temperature: 125°C; Min Operating Temperature: -40°C; Package Quantity: 2000; Packaging: Tape and Reel; Pricing 1: 1=0,46000, 10=0,42200, 50=0,42200, 100=0,33800, 1000=0,26000, 10000=0,20200 (USD); REACH SVHC: No SVHC; Resistor Type: Cermet; RoHS: Yes; RoHS Compliant: Yes; Stock 1: 1799; Supplier 1: Mouser; Termination: SMD/SMT; Type: Potentiometer; Voltage Rating (DC): 50V; Width: 1.55mm
U21 U22 = CMP-04892-000071-1: 1-CHANNEL, 8:1 GENERAL-PURPOSE A; Component Kind: Standard; Library Name: interface_Schlib.SchLib
U23 U24 U32 = SN74LV1T04DCKRG4: Single Power Supply INVERTER Gate logic level shifter 5-SC70; Manufacturer: Texas Instruments; High Level Output Current: 8mA; Number of Circuits: 1; Propagation Delay: 7ns; Quiescent Current: 10uA; Category: Logic Gates; Component Kind: Standard; ComponentLink1Description: http://www.ti.com/general/docs/suppproductinfo.tsp?distId=10&amp;gotoUrl=http%3A%2F%2Fwww.ti.com%2Flit%2Fgpn%2Fsn74lv1t04&src-supplier=Digi-Key; ComponentLink1URL: http://www.ti.com/general/docs/suppproductinfo.tsp?distId=10&amp;gotoUrl=http%3A%2F%2Fwww.ti.com%2Flit%2Fgpn%2Fsn74lv1t04&src-supplier=Digi-Key; Library Name: interface_Schlib.SchLib; Logic Function: Inverter; Low Level Output Current: 8mA; Manufacturer Part Number: SN74LV1T04DCKRG4; Max Operating Temperature: 125°C; Min Operating Temperature: -40°C; Mount: Surface Mount; Number of Inputs: 1; Packaging: Cut Tape; Pricing 1: 1=0,56000, 10=0,47800, 50=0,47800, 100=0,33100, 1000=0,21700, 10000=0,19700 (USD); RoHS: Yes; RoHS Compliant: Yes; Stock 1: 13588; Supplier 1: Mouser
U31 = OPA607QDBVRQ1: Automotive, 50-MHz, low-power, gain of 6-V/V stable, rail-to-rail output CMOS operational amplifier 5-SOT-23 -40 to 125; Manufacturer: Texas Instruments; Component Kind: Standard; Library Name: interface_Schlib.SchLib; Manufacturer Part Number: OPA607QDBVRQ1; Pricing 1: 1=1,44000, 10=1,30000, 25=1,23000, 50=1,23000, 100=1,01000, 250=0,94200, 500=0,83200, 1000=0,65700, 3000=0,63500, 10000=0,63500 (USD); RoHS: Yes; Stock 1: 4836; Supplier 1: Mouser
